# Altium schematic sheet Sheet1.SchDoc(Sheet1)
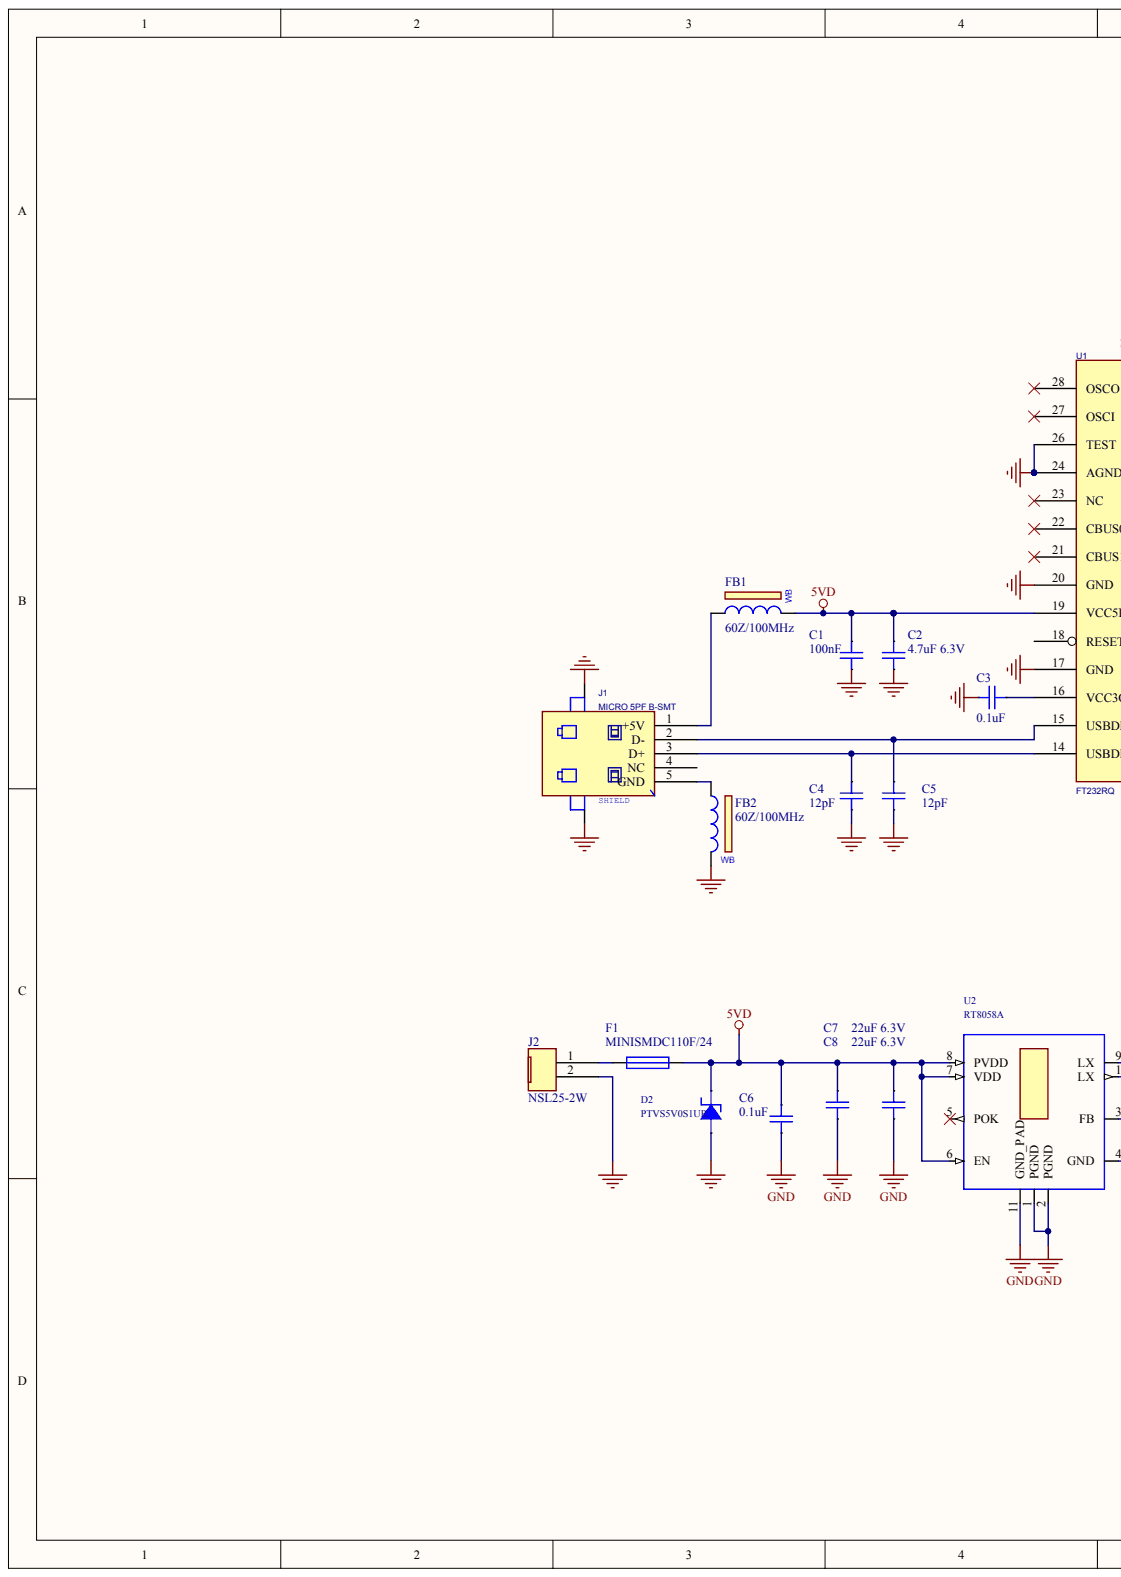
[diagram p0: Sheet1.SchDoc, left]
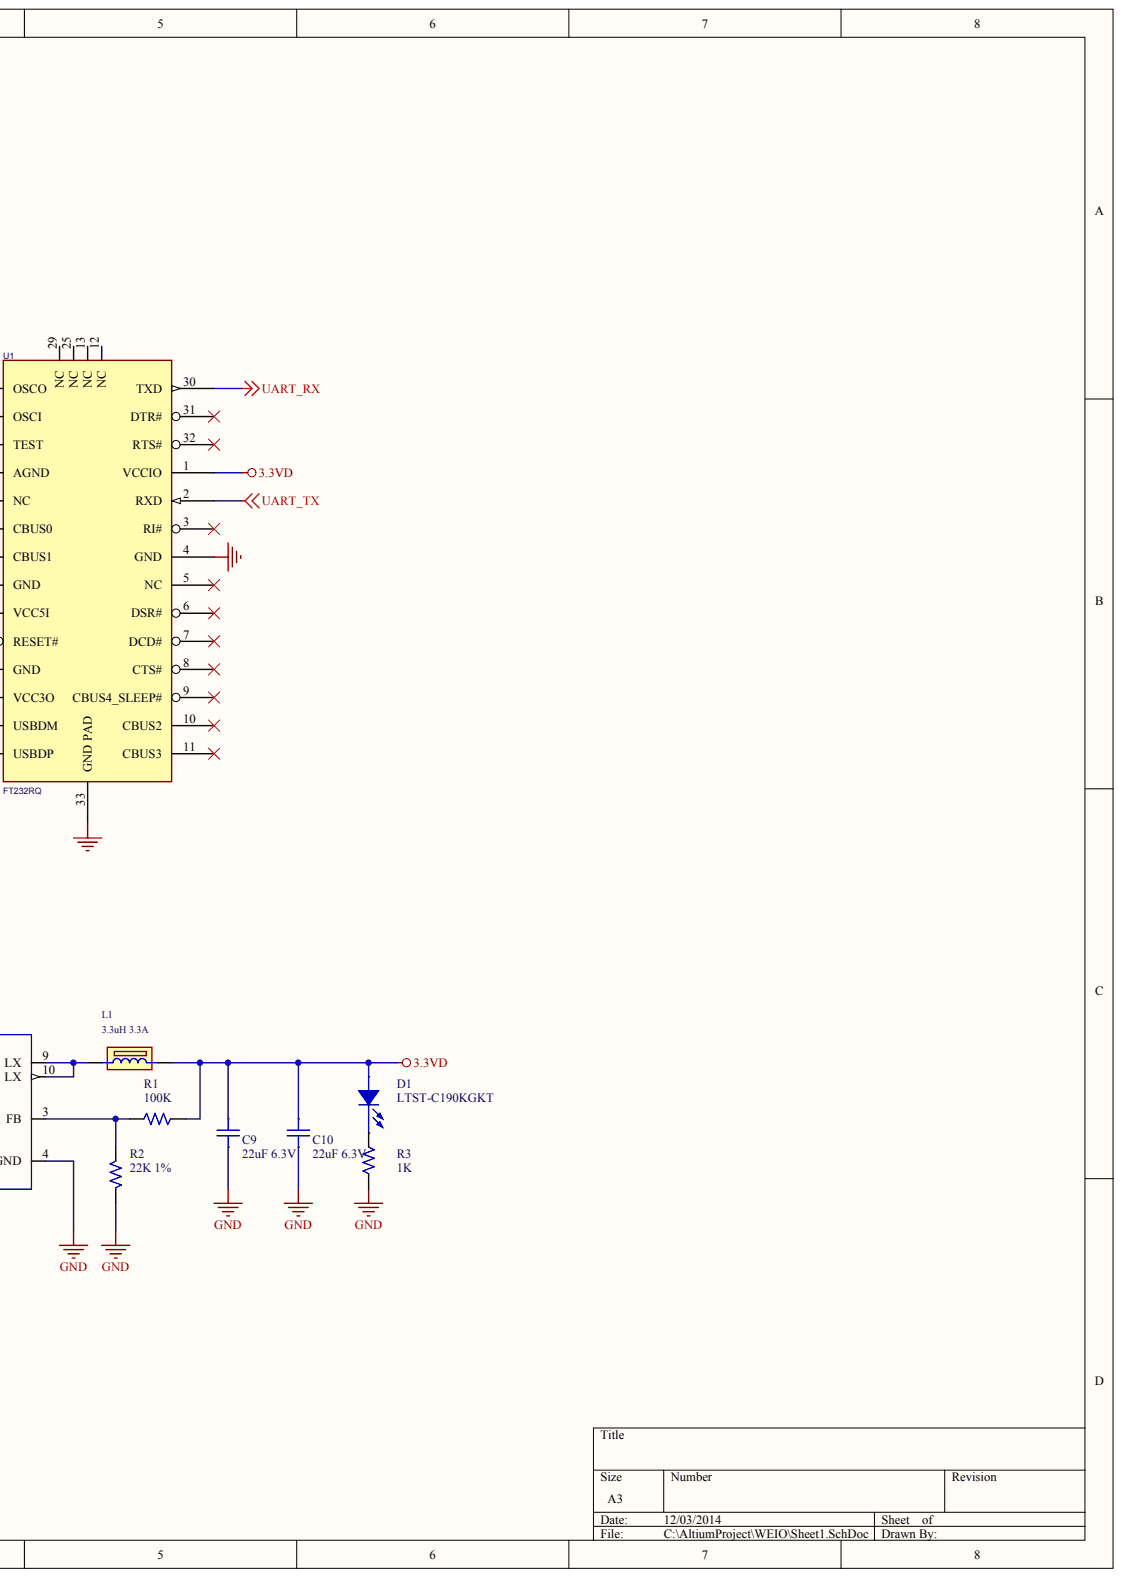
[diagram p1: Sheet1.SchDoc, right]

## Parts
C1  100nF
C2  Voltage: 6.3V  (hidden comment: 4.7uF 6.3V)
C3  value 0.1uF  (hidden comment: 100nF)
C4  12pF
C5  12pF
C6  value 0.1uF  (hidden comment: 100nF)
C7  22uF 6.3V
C8  22uF 6.3V
C9  22uF 6.3V
C10  Voltage: 6.3V  (hidden comment: 22uF 6.3V)
D1  LTST-C190KGKT
D2  PTVS5V0S1UR
F1  MINISMDC110F/24
FB1  60Z/100MHz
FB2  60Z/100MHz
J1  MICRO 5PF B-SMT
J2  NSL25-2W
L1  3.3uH 3.3A
R1  100K
R2  22K 1%
R3  1K
U1  FT232RQ
U2  RT8058A

## Nets
3.3VD: C9.1 C10.1 D1.1 L1.2 R1.1 U1.1(VCCIO)
5VD: C1.1 C2.1 C6.1 C7.2 C8.2 D2.2 F1.2 FB1.2 U1.19(VCC5I) U2.6(EN) U2.7(VDD) U2.8(PVDD)
GND: C1.2 C2.2 C3.2 C4.2 C5.2 C6.2 C7.1 C8.1 C9.2 C10.2 D2.1 FB2.2 J1.6 J1.7 J2.2 R2.1 R3.1 U1.4(GND) U1.17(GND) U1.20(GND) U1.24(AGND) U1.26(TEST) U1.33(GND_PAD) U2.1(PGND) U2.2(PGND) U2.4(GND) U2.11(GND_PAD)
UART_RX: U1.30(TXD)
UART_TX: U1.2(RXD)
NetC3_1: C3.1 U1.16(VCC3O)
NetC4_1: C4.1 J1.3(D+) U1.14(USBDP)
NetC5_1: C5.1 J1.2(D-) U1.15(USBDM)
NetD1_2: D1.2 R3.2
NetF1_1: F1.1 J2.1
NetFB1_1: FB1.1 J1.1(+5V)
NetFB2_1: FB2.1 J1.5(GND)
NetL1_1: L1.1 U2.9(LX) U2.10(LX)
NetR1_2: R1.2 R2.2 U2.3(FB)

Not connected: J1 4(NC); U1 3(RI#) 5(NC) 6(DSR#) 7(DCD#) 8(CTS#) 9(CBUS4_SLEEP#) 10(CBUS2) 11(CBUS3) 12(NC) 13(NC) 18(RESET#) 21(CBUS1) 22(CBUS0) 23(NC) 25(NC) 27(OSCI) 28(OSCO) 29(NC) 31(DTR#) 32(RTS#); U2 5(POK)

## Library data of the parts
C1 C6 = (no symbol name): Part Number: 00005500; Manufacturer Part Number: C1005X5R1C104K; Manufacturer: TDK; Voltage: 16V; Tolerance: +-10%; PSpice: C; Part Type: Ceramic\1005; RoHS: Yes; Availability: In Stock; Datasheet: E:\pdf\KONDENSATORIAI\CER_smd\e412_c.pdf
  C1: Value: 100nF
C2 = (no symbol name): Part Number: 00000560; Manufacturer Part Number: C1608X5R0J475K; Manufacturer: TDK; Tolerance: +-10%; PSpice: C; Value: 4.7uF 6.3V; Part Type: Ceramic\1608; RoHS: Yes; Package: 180mmT&R4000; Availability: In Stock; Datasheet: E:\pdf\KONDENSATORIAI\CER_smd\e412_c.pdf
C3 = (no symbol name): Manufacturer: TDK; Manufacturer Part Number: C1005X5R1C104K; Part Number: 00005500; Voltage: 16V; Tolerance: +-10%; PSpice: C; RoHS: Yes; Part Type: Ceramic\1005; Availability: In Stock; Datasheet: E:\pdf\KONDENSATORIAI\CER_smd\e412_c.pdf
C4 C5 = (no symbol name): Part Number: 00005419; Manufacturer Part Number: CL05C120JB5NNNC; Manufacturer: Samsung; Voltage: 50V; Tolerance: +-5%; PSpice: C; Gaminys: TM1; Value: 12pF; Part Type: Ceramic\1005; RoHS: Yes; Availability: In Stock; Data: 26/05/2008; Datasheet: E:\pdf\KONDENSATORIAI\CER_smd\CL05C120JB5NNNC1.pdf
C7-C10 = (no symbol name): Part Number: 00000312; Manufacturer Part Number: GRM21BR60J226ME39L; Manufacturer: MURATA; Tolerance: +-20%; PSpice: C; Gaminys: TM2; Value: 22uF 6.3V; Part Type: Ceramic\2012; RoHS: Yes; Distributor Part Number: 81-GRM21R60J226ME39L; Distributor: MOUSER; Price: 0.816; Package: 180mm Emb T&R3000; Datasheet: E:\pdf\KONDENSATORIAI\CER_SMD\c02e.pdf
  C7-C9: Voltage: 6.3V
D1 = (no symbol name): Manufacturer: LITEON; Part Number: 32000102; Rating: 100mW; PSpice: D; SMD: Yes; Manufacturer Part Number: LTST-C190KGKT; Distributor Part Number: 160-1183-2-ND; Distributor: Digi-Key; Price: 0.113; Part Type: Diode\LED SMD; Package: 3000T&R; Datasheet: E:\pdf\diodai\led\ltst-c190ckt.pdf
D2 = (no symbol name): Manufacturer: NXP Semiconductors; Manufacturer Part Number: PTVS5V0S1UR,115; Part_Number: 33010905W; Komentaras: $; Layout PCB Footprint: SOD123_W; COMPHEIGHT: 1.1; Price: 0.12; Distributor: Digi-Key; Distributor Part Number: 568-6546-2-ND; SMD: Yes
FB1 FB2 = (no symbol name): Part Number: 20000001; Manufacturer Part Number: MI1608-600; Manufacturer: Coilmaster Electronics Co,,Ltd.; COMPHEIGHT: 0.8; Tolerance: 25%; Allegro PCB Footprint: MI1608_I; PSpice: L; Lead time: 3-4w; Part Type: Inductor\Ferrite beads 1608; SMD: Yes; Price: 0.03; Availability: 3-4weeks; Main distributor: Coilmaster; MOQ: 4000; ActivepartsID: http://www.coilmaster.com.tw/Web%20Site%20Catalogue%202007/T-MB,MI/MI1608-LF-RoHS.pdf; Data: 2009-05-15; Value: 60Z/100MHz; Datasheet: E:\pdf\induktyvumai\smd\MI1608-600-3A-LF-Teltonika.pdf
J1 = (no symbol name): Manufacturer: DongGuang XunWang Electronic Technology Co.,Ltd.; Manufacturer Part Number: XW-105-12; Part_Number: 72300028W; Layout PCB Footprint: XW-105-12_MIKROUSB_5PF_B-SMT; COMPHEIGHT: 2.45; SMD: Yes
J2 = (no symbol name): Value: 2POS, 2.5MM; Rating: 250V; Part Type: Connector; RoHS: Yes; Distributor Part Number: 00 9276 002 021 106; Distributor: FARNEL; Price: 0.0731; Datasheet: E:\pdf\JUNGTYS\
L1 = (no symbol name): Part_Number: 20100086W; Manufacturer Part Number: NR5040T3R3N; Manufacturer: Taiyo Yuden; COMPHEIGHT: 4.0; Layout PCB Footprint: NR5040_5.1x5.1x4.0; Part Type: Power Inductor\Shielded; SMD: Yes; Distributor Part Number: 587-2371-2-ND; Distributor: Digi-key; Price: 0.16
R1 = (no symbol name): Part Number: 10012142; Value: 100K
R2 = (no symbol name): Part Number: 10000184; TOLERANCE: 5%; PSpiceTemplate: R^@REFDES %1 %2 ?TOLERANCE|R^@REFDES| @VALUE  ?TOLERANCE|\n.model R^@REFDES RES R=1 DEV=@TOLERANCE%|; COMPHEIGHT: 0.45; Power: 1/10W; Allegro PCB Footprint: R1608_I; PSpice: R; Part type: Carbon Film; SMD: Yes; Distributor Part Number: 1001415; Distributor: Elgerta; Price: 0.00367; Availability: In Stock; Value: 22K 1%
R3 = (no symbol name): Part Number: 10011080; Manufacturer Part Number: RC0402JR-1KL; Manufacturer: YAGEO; Power: 1/16W; Tolerance: 5%; PSpice: R; Value: 1K; Part type: SMD\0402; RoHS: Yes; Availability: In Stock; Datasheet: E:\pdf\rezistoriai\PSG_R-chip_Yageo_2005.pdf
U1 = (no symbol name): Manufacturer: FTDI Chip; Part_Number: 50600302; Komentaras: $; COMPHEIGHT: 0.9; Layout PCB Footprint: QFN32_0.5_5X5; Price: 2.17; Distributor: Digi-Key; Distributor Part Number: 768-1008-2-ND; Manufacturer Part Number: FT232RQ; SMD: Yes
U2 = (no symbol name): Part_Number: 50800011WA; Manufacturer Part Number: RT8058AGQW; Manufacturer: Richtek USA Inc; COMPHEIGHT: 0.9; Layout PCB Footprint: DFN10_0.5_3.1X3.1_A; Komentaras: $; SMD: Yes; Distributor Part Number: RT8058AGQW-ND; Distributor: Digi-Key; Price: 0.37
F1 = (no symbol name): Published: 8-Jun-2000; LatestRevisionDate: 17-Jul-2002; LatestRevisionNote: Re-released for DXP Platform.; value: MINISMDC110F/24; Publisher: Altium Limited
